# Altium schematic sheet Offset_Attenuator.SchDoc(Offset_Attenuator)
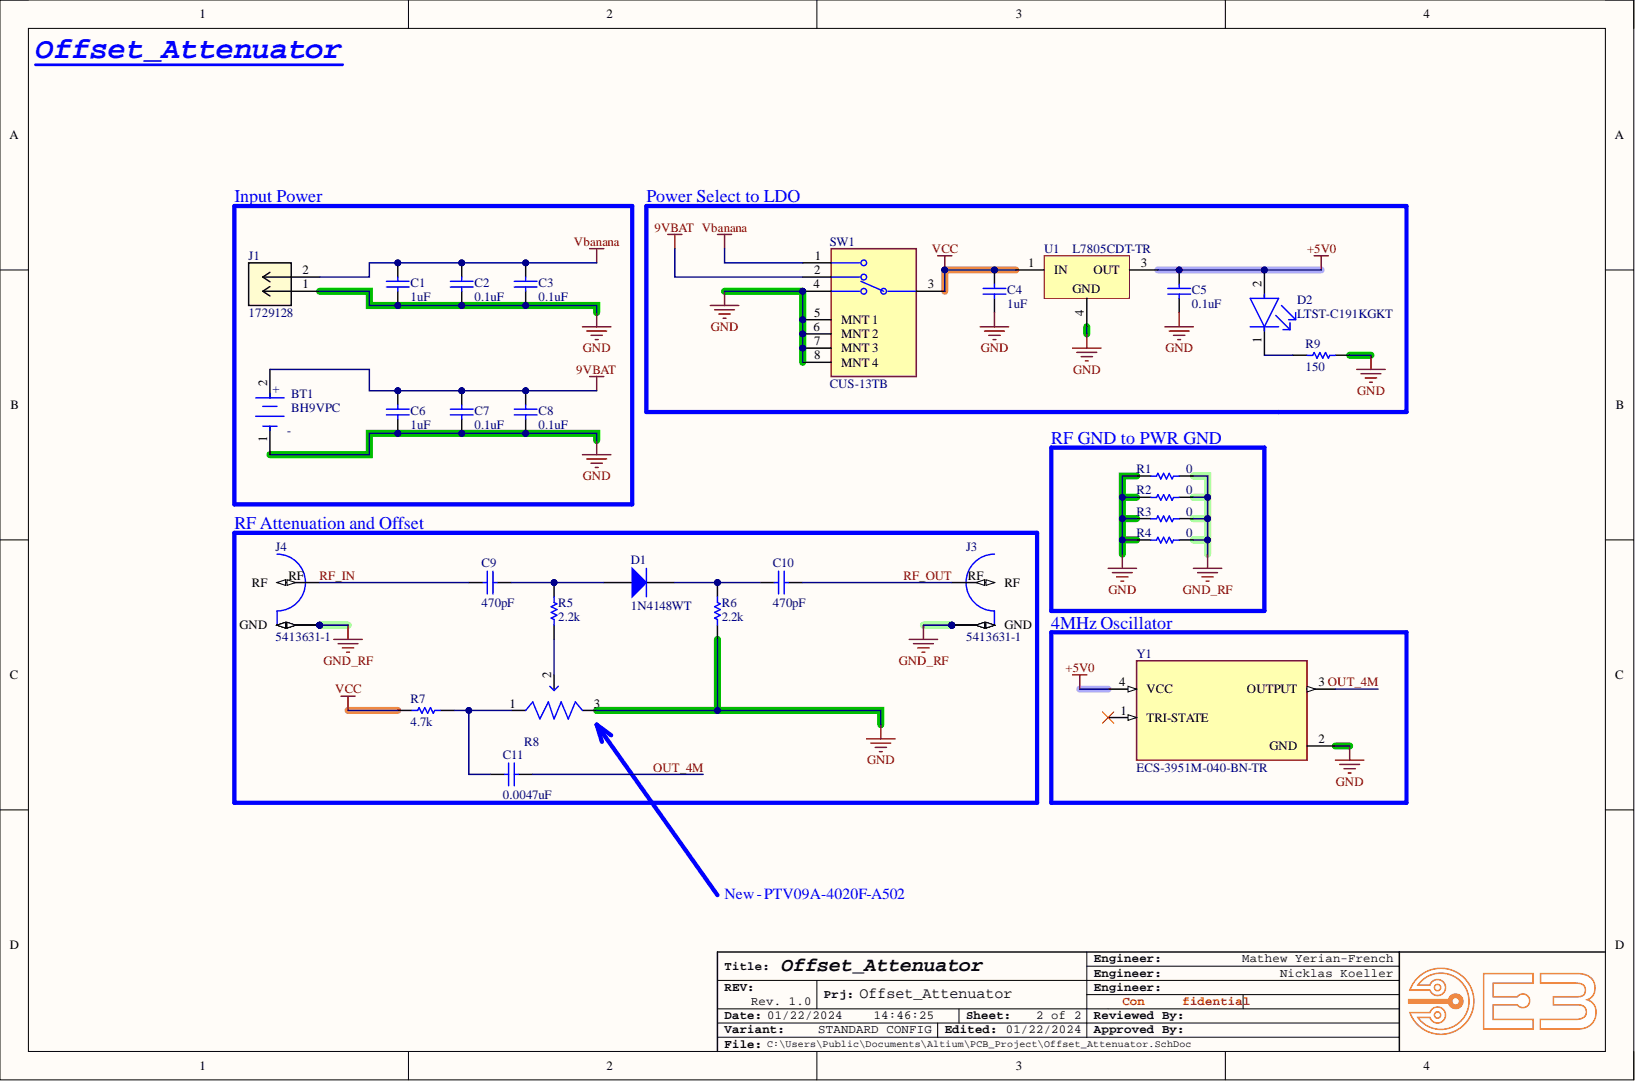
[diagram p0: Offset_Attenuator.SchDoc]

## Parts
BT1  BH9VPC  [FP-BH9VPC-MFG]
C1  value 1uF  [0603CAP]  (hidden comment: C0603)
C2  value 0.1uF  [0603CAP]  (hidden comment: C0603)
C3  value 0.1uF  [0603CAP]  (hidden comment: C0603)
C4  value 1uF  [0603CAP]  (hidden comment: C0603)
C5  value 0.1uF  [0603CAP]  (hidden comment: C0603)
C6  value 1uF  [0603CAP]  (hidden comment: C0603)
C7  value 0.1uF  [0603CAP]  (hidden comment: C0603)
C8  value 0.1uF  [0603CAP]  (hidden comment: C0603)
C9  value 470pF  [0402cap]  (hidden comment: C0402)
C10  value 470pF  [0402cap]  (hidden comment: C0402)
C11  value 0.0047uF  [0603CAP]  (hidden comment: C0603)
D1  1N4148WT  [FAIR-SOD-523F-2_V]
D2  LTST-C191KGKT  [FP-LTST-C191KGKT-MFG]
J1  1729128  [PHOE-1729128-2_V]
J3  5413631-1  [5413631-1]
J4  5413631-1  [5413631-1]
R1  value 0  [0805RES]  (hidden comment: R0805)
R2  value 0  [0805RES]  (hidden comment: R0805)
R3  value 0  [0805RES]  (hidden comment: R0805)
R4  value 0  [0805RES]  (hidden comment: R0805)
R5  CR1206F2K20P05Z: 2.2k  [RESC3216X60X45ML15T20]  (hidden comment: Resistor 0R +/- 5% 1206 250 mW)
R6  CR1206F2K20P05Z: 2.2k  [RESC3216X60X45ML15T20]  (hidden comment: Resistor 0R +/- 5% 1206 250 mW)
R7  RMC12064.7K5%N: 4.7k  [RESC3216X60X45ML15T20]  (hidden comment: Resistor 0R +/- 5% 1206 250 mW)
R8  EVU-F2LFL3D53  [EVU-F2LFL3D53]  (value not drawn)
R9  value 150  [0603RES]  (hidden comment: R0603)
SW1  CUS-13TB  [FP-CUS-13TB-MFG]
U1  L7805CDT-TR  [TO-252_N]
Y1  ECS-3951M-040-BN-TR  [OSC_ECS-3951M-040-BN-TR]

## Nets
9VBAT: BT1.2 C6.2 C7.2 C8.2 SW1.2
+5V0: C5.2 D2.2 U1.3 Y1.4(VCC)
GND: BT1.1 C1.1 C2.1 C3.1 C4.1 C5.1 C6.1 C7.1 C8.1 J1.1 R1.1 R2.1 R3.1 R4.1 R6.1 R8.3 R9.2 SW1.4 SW1.5(MNT_1) SW1.6(MNT_2) SW1.7(MNT_3) SW1.8(MNT_4) U1.4 Y1.2(GND)
GND_RF: J3.G1 J3.G2 J3.G3 J3.G4 J4.G1 J4.G2 J4.G3 J4.G4 R1.2 R2.2 R3.2 R4.2
Vbanana: C1.2 C2.2 C3.2 J1.2 SW1.1
VCC: C4.2 R7.2 SW1.3 U1.1
OUT_4M: C11.2 Y1.3(OUTPUT)
RF_IN: C9.1 J4.RF
RF_OUT: C10.2 J3.RF
NetC9_2: C9.2 D1.2 R5.2
NetC10_1: C10.1 D1.1 R6.2
NetC11_1: C11.1 R7.1 R8.1
NetD2_1: D2.1 R9.1
NetR5_1: R5.1 R8.2

Not connected: Y1 1(TRI-STATE)

## Library data of the parts
BT1 = CMP-136216-000001-1: Battery Holder (Open) 9V 1 Cell PC Pin; Manufacturer: Memory Protection Devices; Conn Orientation: Vertical; Is Female: yes; Number Of Contacts: 2; Automotive: No; Category: Batt; Component Kind: Standard; ComponentLink2Description: Datasheet; ComponentLink2URL: http://www.memoryprotectiondevices.com/datasheets/BH9VPC-datasheet.pdf; Contact Material: Nickel; Device Class L1: Connectors; Device Class L2: unset; Device Class L3: unset; Digikey Description: BATTERY HOLDER 9V PC PIN; Height: 21.12mm; Lead Free: Yes; Library Name: Altium Content Vault; Manufacturer Part Number: BH9VPC; Octopart: https://octopart.com/bh9vpc-memory+protection+devices-22788154; Package: 0; RoHS: TRUE; Voltage Rating: 9V
C1-C8 C11 = C0603: 0603 Ceramic Chip Capacitor - Standard; Component Kind: Standard; Library Name: Standard Components.IntLib; Signal Integrity: C0603; Simulation: CAP
C9 C10 = C0402: 0402 Ceramic Chip Capacitor - Standard; Component Kind: Standard; Library Name: Standard Components.IntLib; Signal Integrity: C0402; Simulation: CAP
D1 = CMP-0881-00001-1: High Conductance Fast Switching Diode, 75 V, -55 to 150 degC, 2-Pin SOD523F, RoHS; Manufacturer: Fairchild Semiconductor; BVR (IR: 5 uA)=75 V Min.; CO: 4 pF Max.; IR (VR: 75 V)=5 uA Max.; VF: 1 V Max.; Component Kind: Standard; ComponentLink1Description: Manufacturer URL; ComponentLink1URL: http://www.fairchildsemi.com/; ComponentLink2Description: Datasheet; ComponentLink2URL: http://www.fairchildsemi.com/ds/1N/1N4148WT.pdf; DatasheetVersion: Rev. A2; Library Name: Altium Content Vault; Mounting Technology: Surface Mount; PackageDescription: 2-Pin Surface Mount Device, Body 1.2 x 0.8 mm, Height 0.7 mm; PackageReference: SOD-523F-2; PartNumber: 1N4148WT; RoHS: TRUE; Temperature Range: -55 to 150 degC
D2 = CMP-2000-05198-2: LED GREEN CLEAR SMD; Dominant Wavelength: 571nm; Forward Current: 30mA; Forward Voltage: 2V; Lens Color: Colorless; Lens Style: Rectangular; Luminous Intensity: 35mcd; Max Power Dissipation: 75mW; Number of Elements: 1; Reverse Voltage: 5V; Test Current: 20mA; Viewing Angle: 130°; Wavelength: 574nm; Case Code (Imperial): 0603; Case Code (Metric): 1608; Case/Package: 0603; Color: Green; Component Kind: Standard; Depth: 0.8mm; Height: 0.55mm; Illumination Color: Green; Lead Free: Lead Free; Length: 1.6mm; Lens Transparency: Clear; Library Name: Altium Content Vault; Max Operating Temperature: 85°C; Min Operating Temperature: -55°C; Mount: Surface Mount; Number of LEDs: 1; Number of Pins: 2; Package Quantity: 1; Packaging: Tape and Reel; Power Dissipation: 75mW; Power Rating: 75mW; Radiation Hardening: No; Width: 0.8mm
J1 = CMP-002-00071-1: Block, Terminal; 13.5 A; 250 V; 5.08 mm; 26 to 16 AWG; 2; Screw; Solder; 4 kV; Component Kind: Standard; Library Name: E3 Compliance LLC
J3 J4 = 5413631-1: Nickel Plating 50 Ohm Right Angle Metalized Jack PCB BNC Connector; MF: TE Connectivity; Number_of_Positions: 1; Availability: Unavailable; Component Kind: Standard; EU_RoHS_Compliance: Compliant with Exemptions; Library Name: 5413631-1.IntLib; MP: 5413631-1; Package: None; Price: None; SnapEDA_Link: https://www.snapeda.com/parts/5413631-1/TE%20Connectivity%20AMP%20Connectors/view-part/463831/?ref=snap; TE_purchase_URL: https://www.te.com/usa-en/product-5413631-1.html?te_bu=Cor&te_type=disp&te_campaign=seda_glo_cor-seda-global-disp-prtnr-fy19-seda-model-bom-cta_sma-317_1&elqCampaignId=32493
R1-R4 = R0805: 0805 Chip Resistor - Standard; Component Kind: Standard; Library Name: Standard Components.IntLib; Signal Integrity: R0805; Simulation: RES
R5-R7 = CMP-009-00002-5: Chip Resistor, 0 Ohm, +/- 5%, 0.25 W, -55 to 155 degC, 1206 (3216 Metric); Power: 250mW; Voltage Rating: 200V; Case/Package: 1206; Component Kind: Standard; Max Operating Temperature: 155°C; Min Operating Temperature: -55°C; Mounting Technology: SM; Pins: 2; RoHS Compliant: True; Tolerance: 5%; Library Name: E3 Compliance LLC
R9 = R0603: 0603 Chip Resistor - Standard; Component Kind: Standard; Library Name: Standard Components.IntLib; Signal Integrity: R0603; Simulation: RES
SW1 = CMP-71745-000014-1: SWITCH SLIDE SP3T 300MA 4V; Actuator Length: 1.5mm; Actuator Material: Nylon; Actuator Type: Standard; Contact Style: Non-Shorting; Electrical Life: 10000Cycles; Max Voltage Rating (DC): 20V; Switch Function: On-On-On; Component Kind: Standard; Contact Material: Copper Alloy; Contact Plating: Gold; Current Rating: 300mA; Library Name: Altium Content Vault; Max Operating Temperature: 70°C; Min Operating Temperature: -20°C; Mount: Surface Mount; Orientation: Right Angle; Packaging: Tape and Reel; Radiation Hardening: No; RoHS Compliant: Yes; Series: CUS; Switch Travel: 1.5mm; Termination: Gull Wing; Throw Configuration: SP3T; Voltage Rating (DC): 4V
U1 = CMP-0244-00216-1: Positive Voltage Regulator, 3-Pin DPAK, Tape and Reel; Component Kind: Standard; ComponentLink1Description: Manufacturer URL; ComponentLink1URL: http://www.st.com; ComponentLink2Description: Datasheet; ComponentLink2URL: http://www.st.com/internet/com/TECHNICAL_RESOURCES/TECHNICAL_LITERATURE/DATASHEET/CD00000444.pdf; DatasheetVersion: 16/11/2011; Library Name: Altium Content Vault; PackageDescription: 3-Pin Transistor Outline Package 2.28 mm Pitch, 9.7 mm Lead Span (DPAK); PackageReference: TO-252; PackageVersion: 2011
Y1 = ECS-3951M-040-BN-TR: SMD CLOCK OSCILLATOR; Availability: Unavailable; Component Kind: Standard; Library Name: ECS-3951M-040-BN-TR.IntLib; MP: ECS-3951M-040-BN-TR; Package: None; Price: None
R8 = EVU-F2LFL3D53: Component Kind: Standard; Library Name: ProjectMainLib.IntLib
